# Altium schematic sheet dv_power.SchDoc(dv_power)
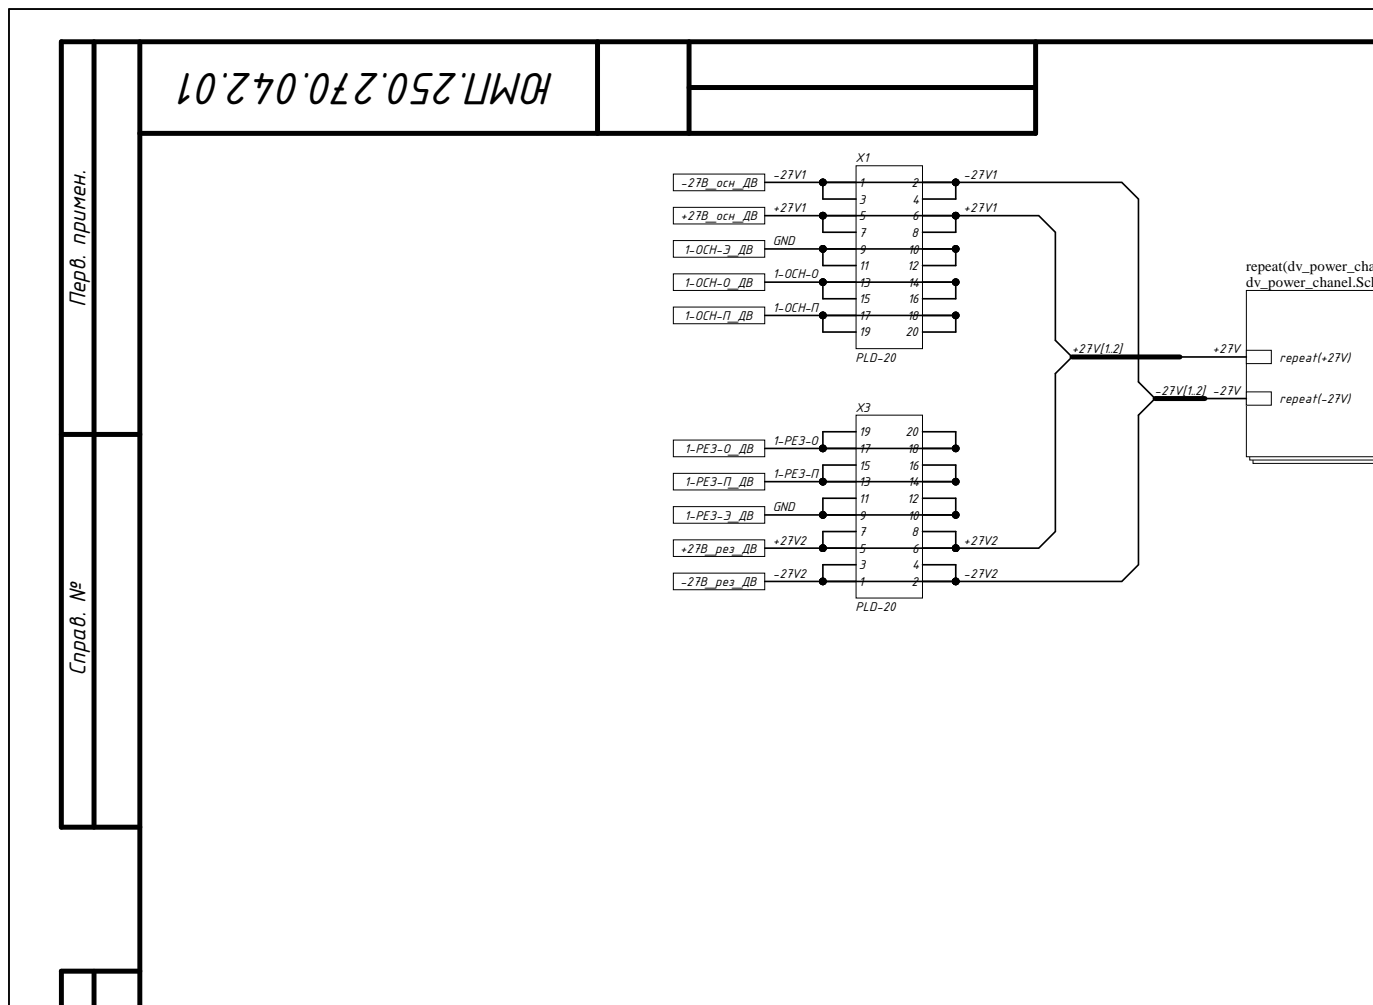
[diagram p0: dv_power.SchDoc, top left]
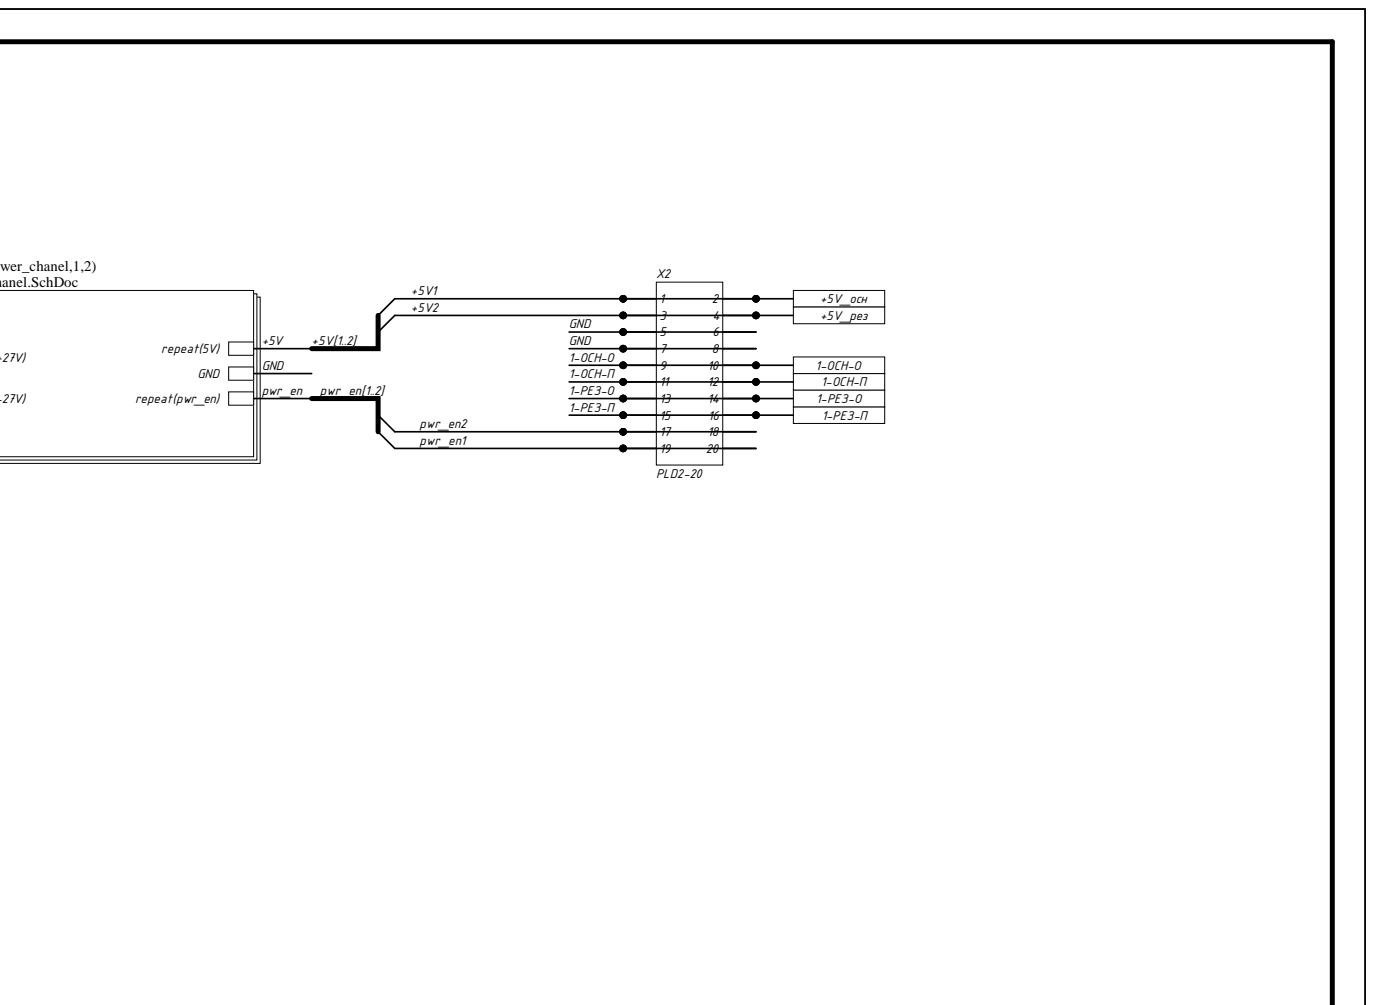
[diagram p1: dv_power.SchDoc, top right]
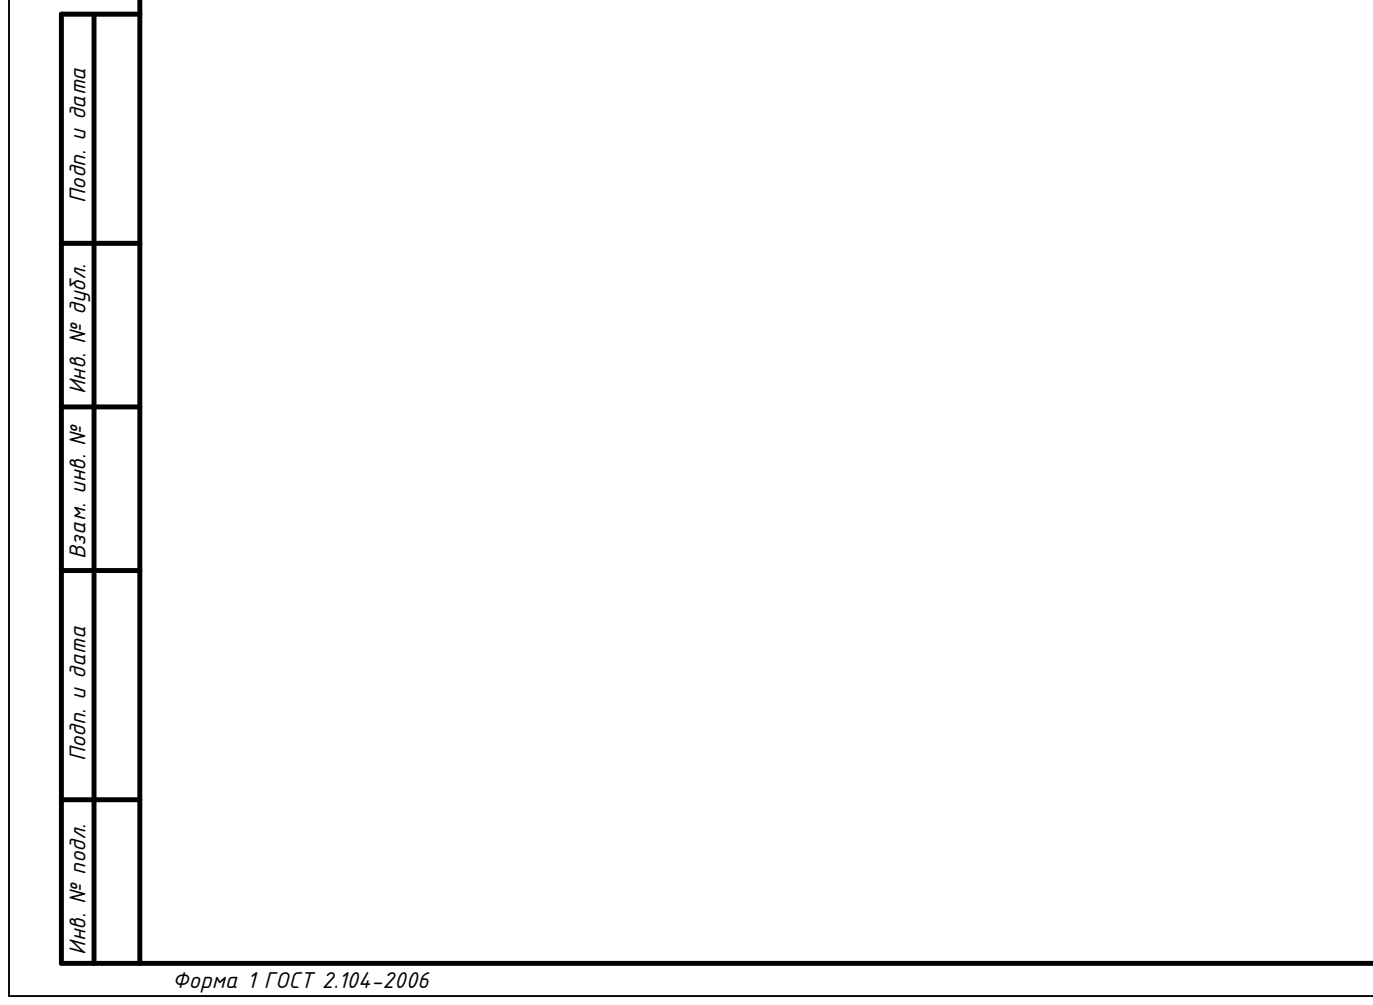
[diagram p2: dv_power.SchDoc, bottom left]
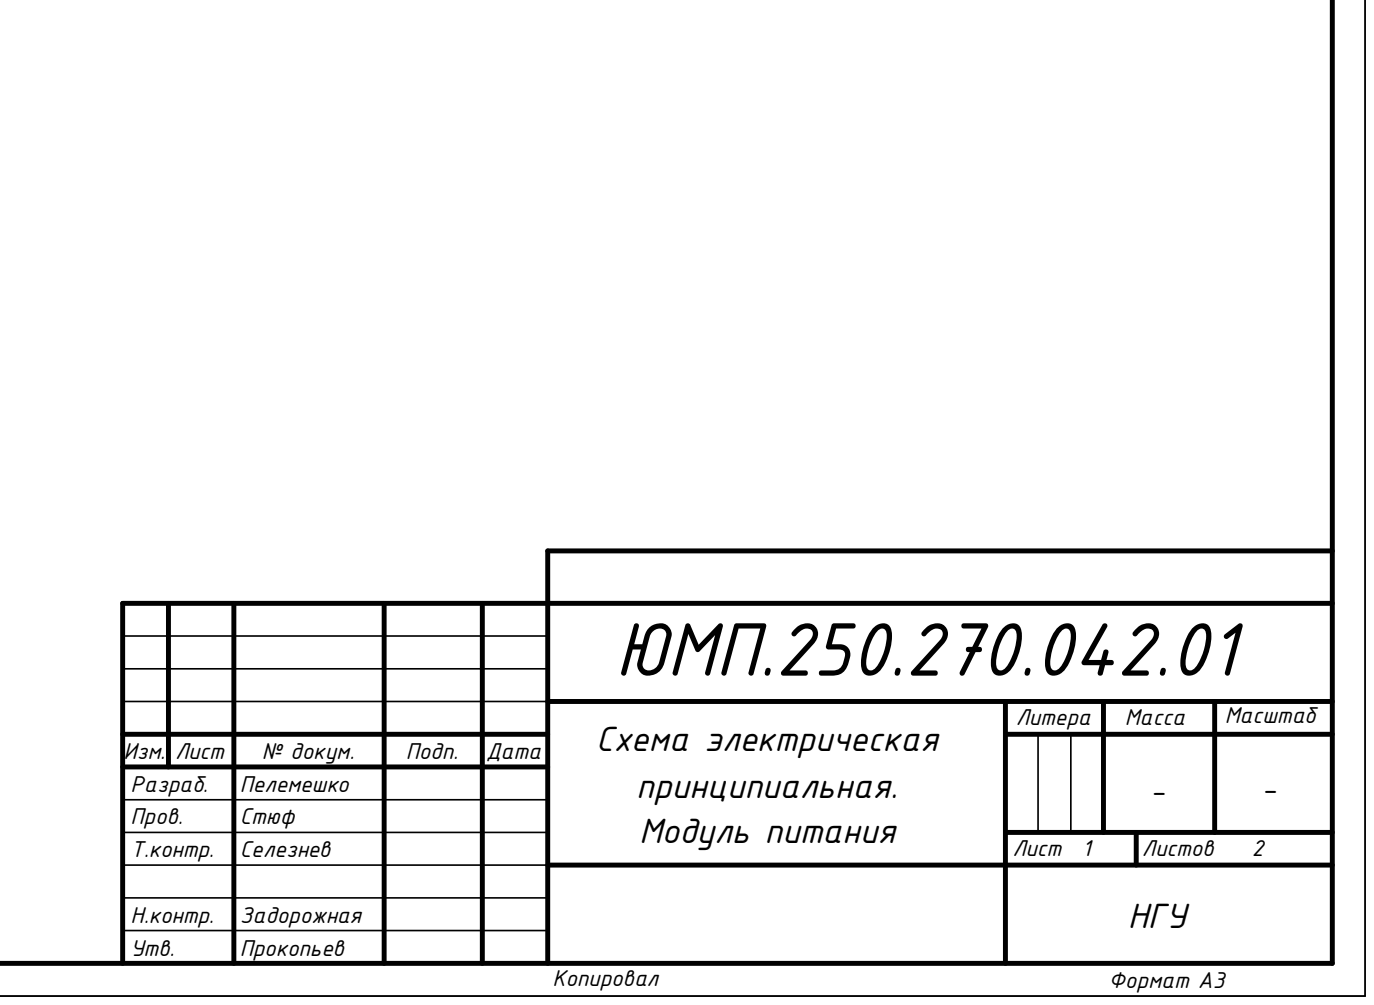
[diagram p3: dv_power.SchDoc, bottom right]

## Parts
X1  Наименование: PLD-20  [PBD-20]  (hidden comment: Header 2X20)
X2  Header 2X20  [PLD2-20]  (value not drawn)
X3  Наименование: PLD-20  [PBD-20]  (hidden comment: Header 2X20)

## Ports
1-ОСН-О, 1-ОСН-О_ДВ, 1-ОСН-П, 1-ОСН-П_ДВ, 1-ОСН-Э_ДВ, 1-РЕЗ-О, 1-РЕЗ-О_ДВ, 1-РЕЗ-П, 1-РЕЗ-П_ДВ, 1-РЕЗ-Э_ДВ, +5V_осн, +5V_рез, +27В_осн_ДВ, +27В_рез_ДВ, -27В_осн_ДВ, -27В_рез_ДВ

## Nets
1-ОСН-О: X1.13 X1.14 X1.15 X1.16 X2.9 X2.10 ; port 1-ОСН-О, port 1-ОСН-О_ДВ
1-ОСН-П: X1.17 X1.18 X1.19 X1.20 X2.11 X2.12 ; port 1-ОСН-П, port 1-ОСН-П_ДВ
1-РЕЗ-О: X2.13 X2.14 X3.17 X3.18 X3.19 X3.20 ; port 1-РЕЗ-О, port 1-РЕЗ-О_ДВ
1-РЕЗ-П: X2.15 X2.16 X3.13 X3.14 X3.15 X3.16 ; port 1-РЕЗ-П, port 1-РЕЗ-П_ДВ
+5V1: X2.1 X2.2 ; port +5V_осн  [also labelled +5V]
+5V2: X2.3 X2.4 ; port +5V_рез  [also labelled +5V]
+27V1: X1.5 X1.6 X1.7 X1.8  [also labelled +27V]
+27V2: X3.5 X3.6 X3.7 X3.8  [also labelled +27V]
-27V1: X1.1 X1.2 X1.3 X1.4  [also labelled -27V]
-27V2: X3.1 X3.2 X3.3 X3.4  [also labelled -27V]
GND: X1.9 X1.10 X1.11 X1.12 X2.5 X2.6 X2.7 X2.8 X3.9 X3.10 X3.11 X3.12 ; port 1-ОСН-Э_ДВ, port 1-РЕЗ-Э_ДВ
pwr_en1: X2.19 X2.20  [also labelled pwr_en]
pwr_en2: X2.17 X2.18  [also labelled pwr_en]

## Library data of the parts
X1-X3 = Header 2X20: Header, 20-Pin, Dual row; Потребление, Вт: 0,0; Component Kind: Standard; Library Name: Connector.IntLib; Назначение: Header, 20-Pin, Dual row
  X2: Наименование: PLD2-20
